# Altium schematic sheet Page4_GSM.SchDoc(Page4_GSM)
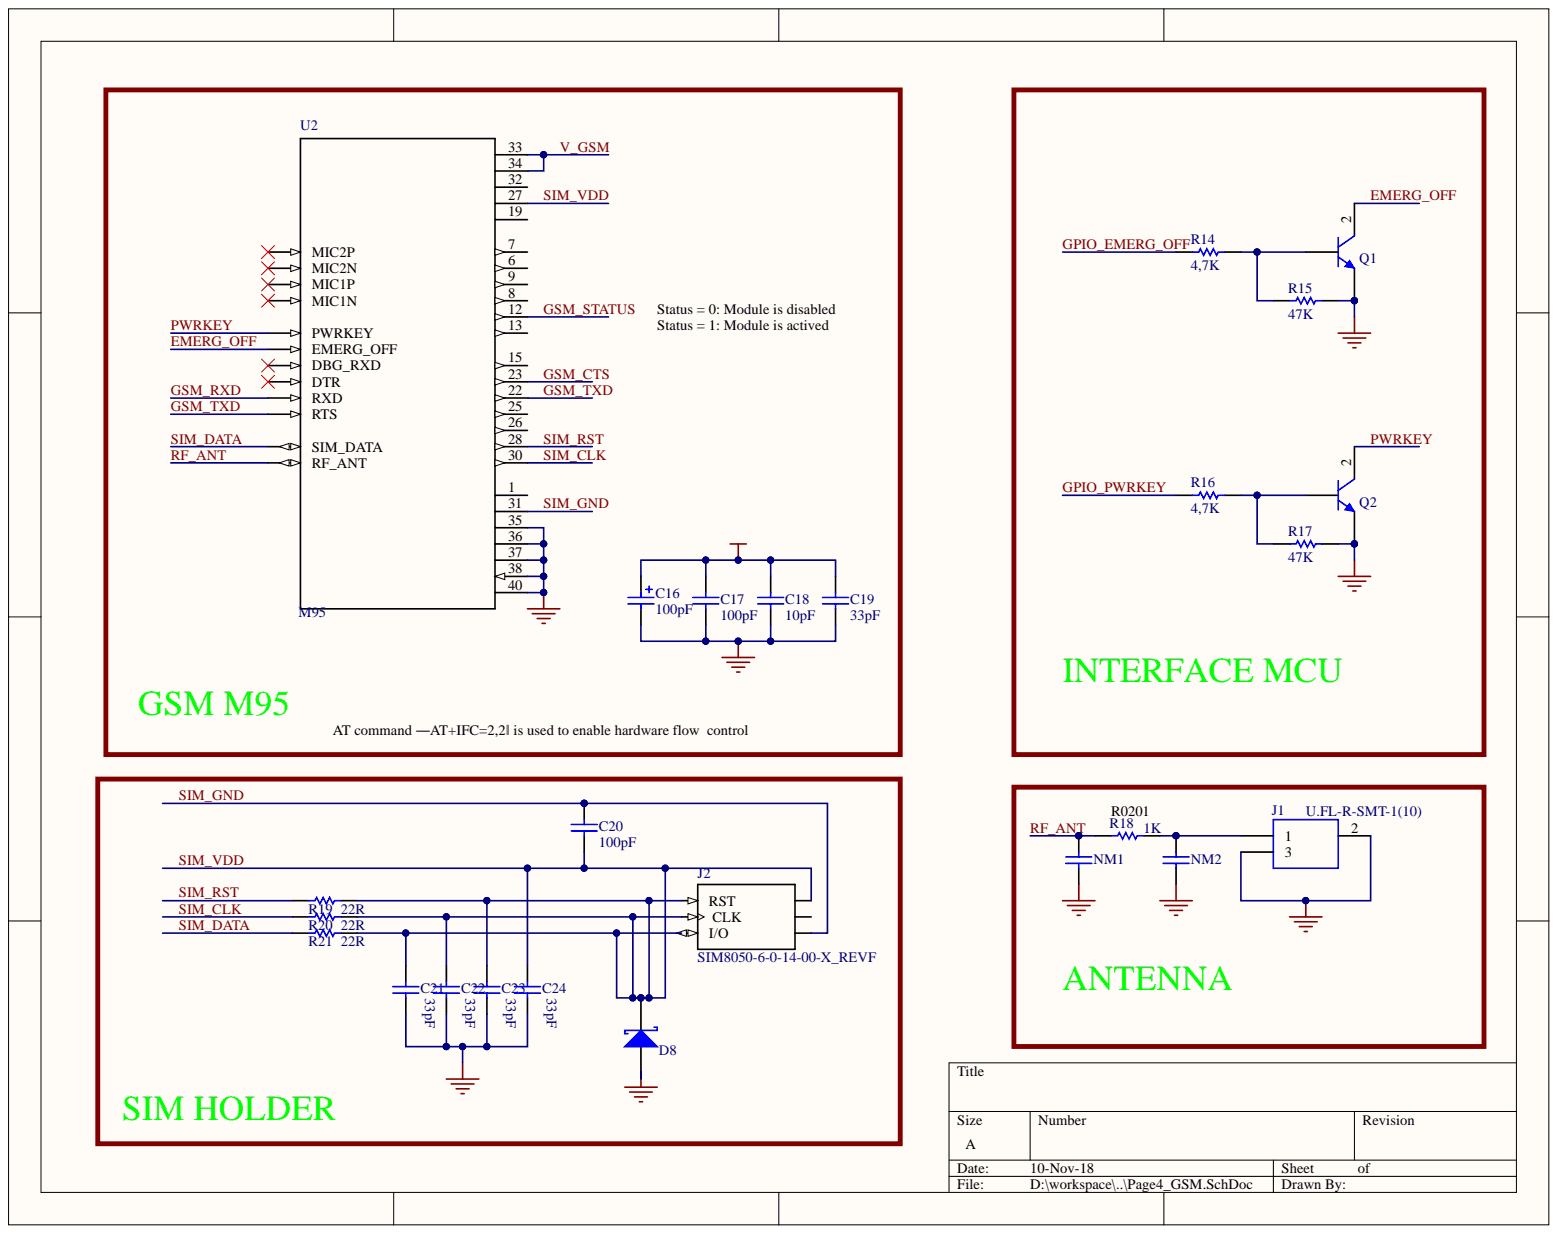
[diagram p0: Page4_GSM.SchDoc]

## Parts
C16  value 100pF  [CAP ALU SIZE B]  (hidden comment: Cap Pol SMD)
C17  value 100pF  [CAP-SM-0603]  (hidden comment: Cap Non-Pol SMD)
C18  value 10pF  [CAP-SM-0603]  (hidden comment: Cap Non-Pol SMD)
C19  value 33pF  [CAP-SM-0603]  (hidden comment: Cap Non-Pol SMD)
C20  value 100pF  [CAP-SM-0603]  (hidden comment: Cap Non-Pol SMD)
C21  value 33pF  [CAP-SM-0603]  (hidden comment: Cap Non-Pol SMD)
C22  value 33pF  [CAP-SM-0603]  (hidden comment: Cap Non-Pol SMD)
C23  value 33pF  [CAP-SM-0603]  (hidden comment: Cap Non-Pol SMD)
C24  value 33pF  [CAP-SM-0603]  (hidden comment: Cap Non-Pol SMD)
D8  D Schottky  [SS34]  (value not drawn)
J1  U.FL-R-SMT-1(10)  [U.FL-R-SMT-1(10)]
J2  SIM8050-6-0-14-00-X_REVF  [GCT_SIM8050-6-0-14-00-X_REVF_SIM8050-6-0-14-00-X_REVF(Primary)]
NM1  Cap Non-Pol SMD  [CAP-SM-0603]  (value not drawn)
NM2  Cap Non-Pol SMD  [CAP-SM-0603]  (value not drawn)
Q1  C1815  [SOT23B]  (value not drawn)
Q2  C1815  [SOT23B]  (value not drawn)
R14  value 4,7K  [RES-SM-0603]  (hidden comment: RESISTOR SMD)
R15  value 47K  [RES-SM-0603]  (hidden comment: RESISTOR SMD)
R16  value 4,7K  [RES-SM-0603]  (hidden comment: RESISTOR SMD)
R17  value 47K  [RES-SM-0603]  (hidden comment: RESISTOR SMD)
R18  value 1K  [RES-SM-0603]  (hidden comment: RESISTOR SMD)
R19  value 22R  [RES-SM-0603]  (hidden comment: RESISTOR SMD)
R20  value 22R  [RES-SM-0603]  (hidden comment: RESISTOR SMD)
R21  value 22R  [RES-SM-0603]  (hidden comment: RESISTOR SMD)
U2  M95  [XCVR_M95]

## Nets
GND: C16.2 C17.1 C18.1 C19.1 C21.1 C22.1 C23.1 C24.1 D8.1 J1.2 J1.3 NM1.1 NM2.1 Q1.1 Q2.1 R15.2 R17.2 U2.35 U2.36 U2.37 U2.38 U2.40
EMERG_OFF: Q1.2 U2.11(EMERG_OFF)
GPIO_EMERG_OFF: R14.1
GPIO_PWRKEY: R16.1
GSM_CTS: U2.23
GSM_RXD: U2.21(RXD)
GSM_STATUS: U2.12
GSM_TXD: U2.22 U2.24(RTS)
PWRKEY: Q2.2 U2.10(PWRKEY)
RF_ANT: NM1.2 R18.1 U2.39(RF_ANT)
SIM_CLK: R20.1 U2.30
SIM_DATA: R21.1 U2.29(SIM_DATA)
SIM_GND: C20.2 J2.C5 U2.31
SIM_RST: R19.1 U2.28
SIM_VDD: C20.1 C21.2 C22.2 C23.2 C24.2 D8.2 J2.C1 J2.C2(RST) J2.C3(CLK) J2.C7(I/O) R19.2 R20.2 R21.2 U2.27
V_GSM: C16.1 C17.2 C18.2 C19.2 U2.33 U2.34
NetJ1_1: J1.1 NM2.2 R18.2
NetQ1_3: Q1.3 R14.2 R15.1
NetQ2_3: Q2.3 R16.2 R17.1

Not connected: J2 C6; U2 1 2(MIC2P) 3(MIC2N) 4(MIC1P) 5(MIC1N) 6 7 8 9 13 14(DBG_RXD) 15 19 20(DTR) 25 26 32

## Library data of the parts
C16 = Cap Pol SMD: Polarized Capacitor (Radial); Library Name: PIF_Library.IntLib; Component Kind: Standard
C17-C24 NM1 NM2 = Cap Non-Pol SMD: Capacitor; Library Name: PIF_Library.IntLib; Component Kind: Standard
D8 = D Schottky: Schottky Diode; Published: 8-Jun-2000; PackageReference: SMB; PackageDescription: DO-214-AA/SMB; 2 C-Bend Leads; Body 5.3 x 3.6 mm, inc. leads (LxW); Library Name: PIF_Library.IntLib; LatestRevisionNote: Re-released for DXP Platform.; LatestRevisionDate: 17-Jul-2002; Component Kind: Standard; Code_JEDEC: DO-214-AA; Publisher: Altium Limited
J1 = U.FL-R-SMT-1(10): UFL SMT PCB receptable,DC-6GHz Hirose Straight 50 Surface Mount UFL Connector, Receptacle, Solder Termination Coaxial; RS Price/Stock: http://uk.rs-online.com/web/p/products/1757510; RS Part Number: 1757510; Manufacturer_Part_Number: U.FL-R-SMT-1(10); Manufacturer_Name: Hirose; Library Name: U.FL-R-SMT-1(10).SchLib; Datasheet Link: https://www.hirose.com/product/en/download_file/key_name/U.FL-R-SMT-1%2810%29/category/Drawing%20(2D)/doc_file_id/37627/?file_category_id=6&item_id=03310472210&is_series=; Component Kind: Standard; Arrow Price/Stock: https://www.arrow.com/en/products/u.fl-r-smt-1-10/hirose-electric; Arrow Part Number: U.FL-R-SMT-1(10)
J2 = SIM8050-6-0-14-00-X_REVF: Nano SIM Retainer, 6P, SMT, 1.35mm Profile, No Peg, Without switch, T&R; MP: SIM8050-6-0-14-00-A; MF: Global Connector Technology; SnapEDA_Link: https://www.snapeda.com/parts/SIM8050-6-0-14-00-A/Global%20Connector%20Technology/view-part/?ref=snap; Price: None; Package: None; Library Name: SIM8050-6-0-14-00-X_REVF.SchLib; Component Kind: Standard; Availability: Unavailable
Q1 Q2 = C1815: NPN Bipolar Transistor; Library Name: PIF_Library.IntLib; Component Kind: Standard
R14-R21 = RESISTOR SMD: Resistor; Library Name: PIF_Library.IntLib; Component Kind: Standard
U2 = M95: Quad-Band Gsm/Gprs Module; MF: Quectel; SnapEDA_Link: https://www.snapeda.com/parts/M95/Quectel/view-part/?ref=snap; Price: None; Package: SMD-16 Quectel; MP: M95; Library Name: M95.IntLib; Component Kind: Standard; Availability: Unavailable
